# Altium schematic sheet E-modem-Send&Receive.SchDoc
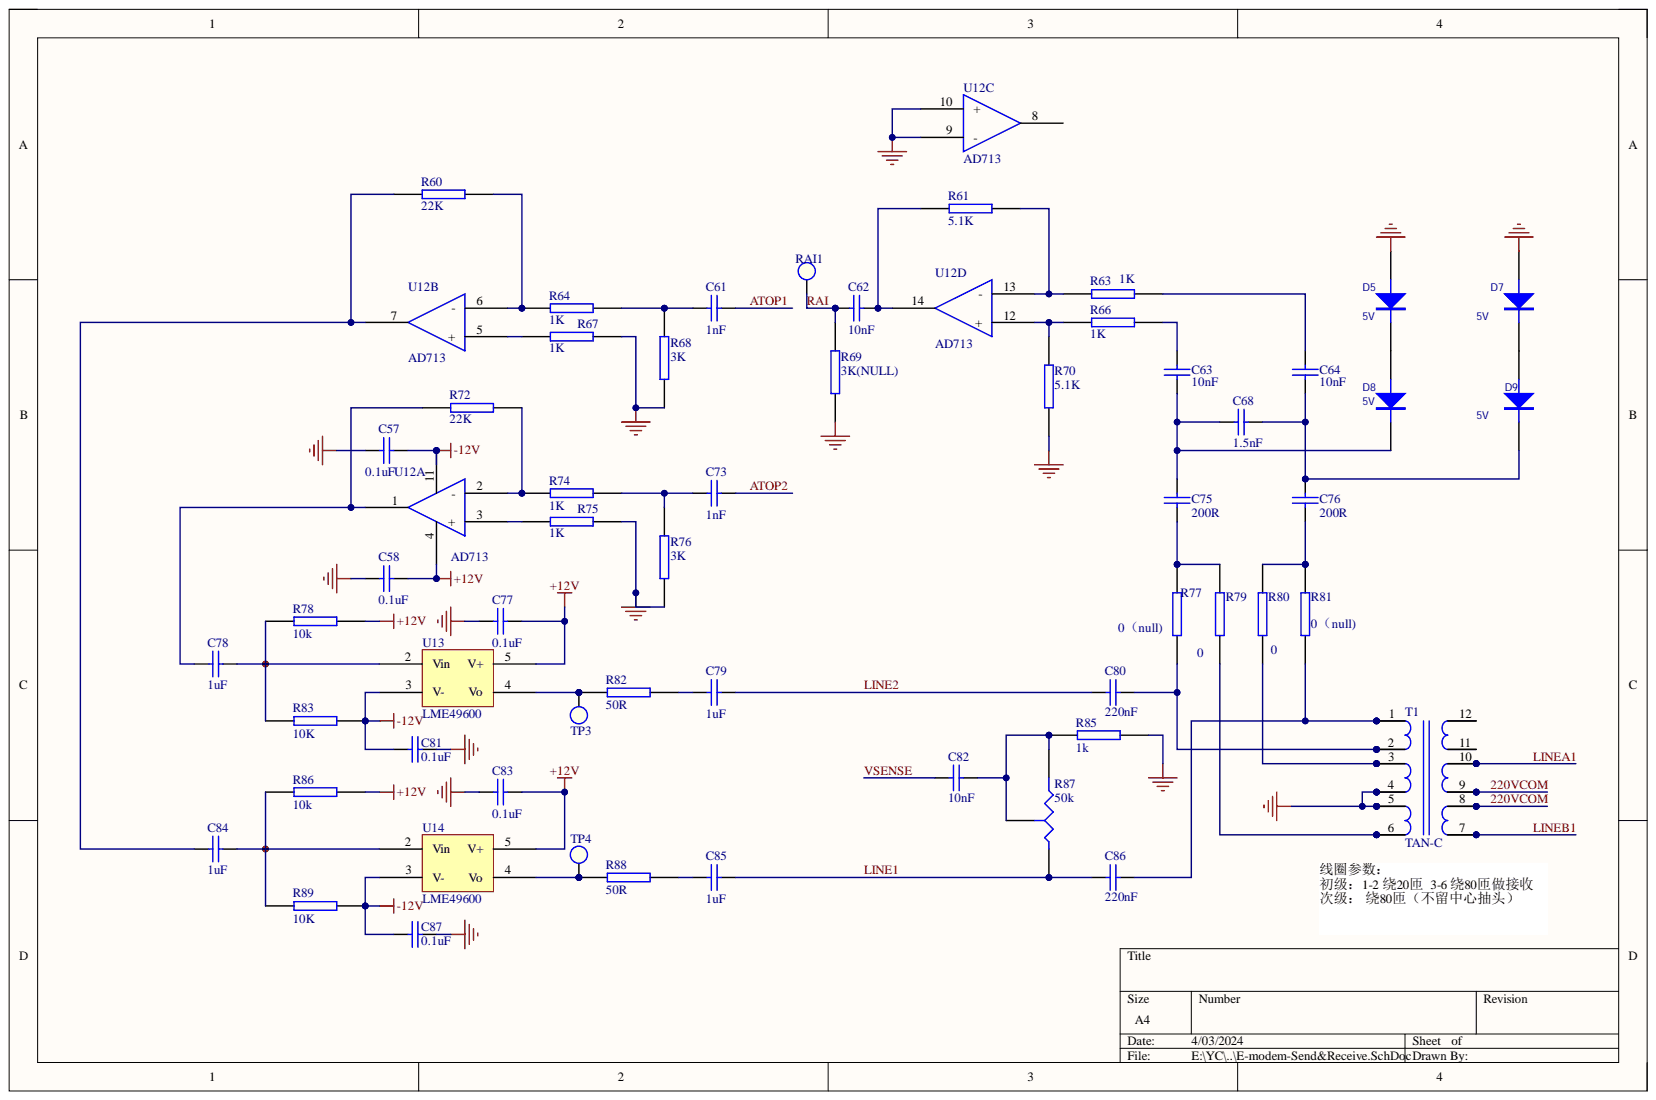
[diagram p0: E-modem-Send&Receive.SchDoc]

## Parts
C57  0.1uF  [0805C]
C58  0.1uF  [0805C]
C61  1nF  [0805C]
C62  10nF  [0805C]
C63  10nF  [0805C]
C64  10nF  [0805C]
C68  1.5nF  [0805C]
C73  1nF  [0805C]
C75  200R  [0805C]
C76  200R  [0805C]
C77  0.1uF  [0805C]
C78  1uF  [0805C]
C79  1uF  [0805C]
C80  220nF  [0805C]
C81  0.1uF  [0805C]
C82  10nF  [0805C]
C83  0.1uF  [0805C]
C84  1uF  [0805C]
C85  1uF  [0805C]
C86  220nF  [0805C]
C87  0.1uF  [0805C]
D5  5V  [1206D]
D7  5V  [1206D]
D8  5V  [1206D]
D9  5V  [1206D]
R60  22K  [0805]
R61  5.1K  [0805]
R63  1K  [0805]
R64  1K  [0805]
R66  1K  [0805]
R67  1K  [0805]
R68  3K  [0805]
R69  3K(NULL)  [0805]
R70  5.1K  [0805]
R72  22K  [0805]
R74  1K  [0805]
R75  1K  [0805]
R76  3K  [0805]
R77  0（null)  [0805]
R78  10k  [0805]
R79  0  [0805]
R80  0  [0805]
R81  0（null)  [0805]
R82  50R  [0805]
R83  10K  [0805]
R85  1k  [0805]
R86  10k  [0805]
R87  50k  [VR4_1]
R88  50R  [0805]
R89  10K  [0805]
RAI1  GND  [TERM]  (value not drawn)
T1  TAN-C  [TAN-C/RM10]
TP3  TERM  [TERM]  (value not drawn)
TP4  TERM  [TERM]  (value not drawn)
U12  AD713  [DIP14]  (parts A,B,C,D)
U13  LME49600  [TO-263-5]
U14  LME49600  [TO-263-5]

## Nets
25: T1.25
26: T1.26
27: T1.27
28: T1.28
+12V: C58.1 C77.1 C83.1 R78.2 R86.2 U12.4 U13.5(V+) U14.5(V+)
-12V: C57.1 C81.1 C87.2 R83.2 R89.1 U12.11 U13.3(V-) U14.3(V-)
GND: C57.2 C58.2 C77.2 C81.2 C83.2 C87.1 D5.1 D7.1 R67.1 R68.1 R69.1 R70.1 R75.2 R76.1 R85.2 T1.4 T1.5 T1.14 T1.15 U12.9(-) U12.10(+)
220VCOM: T1.8 T1.9 T1.23 T1.24
ATOP1: C61.2
ATOP2: C73.2
LINE1: C85.2 C86.1 R87.1
LINE2: C79.1 C80.1
LINEA1: T1.10 T1.19
LINEB1: T1.7 T1.22
RAI: C62.1 R69.2 RAI1.1
VSENSE: C82.1
NetC61_1: C61.1 R64.2 R68.2
NetC62_2: C62.2 R61.1 U12.14
NetC63_1: C63.1 R66.2
NetC63_2: C63.2 C68.2 C75.2 D8.2
NetC64_1: C64.1 R63.2
NetC64_2: C64.2 C68.1 C76.2 D9.2
NetC73_1: C73.1 R74.2 R76.2
NetC75_1: C75.1 R77.2 R79.2
NetC76_1: C76.1 R80.2 R81.1
NetC78_1: C78.1 R72.1 U12.1
NetC78_2: C78.2 R78.1 R83.1 U13.2(Vin)
NetC79_2: C79.2 R82.2
NetC80_2: C80.2 R77.1 T1.2 T1.18
NetC82_2: C82.2 R85.1 R87.2 R87.3
NetC84_1: C84.1 R60.1 U12.7
NetC84_2: C84.2 R86.1 R89.2 U14.2(Vin)
NetC85_1: C85.1 R88.2
NetC86_2: C86.2 R81.2 T1.1 T1.17
NetD5_2: D5.2 D8.1
NetD7_2: D7.2 D9.1
NetR60_2: R60.2 R64.1 U12.6(-)
NetR61_2: R61.2 R63.1 U12.13(-)
NetR66_1: R66.1 R70.2 U12.12(+)
NetR67_2: R67.2 U12.5(+)
NetR72_2: R72.2 R74.1 U12.2(-)
NetR75_1: R75.1 U12.3(+)
NetR79_1: R79.1 T1.6 T1.16
NetR80_1: R80.1 T1.3 T1.13
NetR82_1: R82.1 TP3.1 U13.4(Vo)
NetR88_1: R88.1 TP4.1 U14.4(Vo)
NetT1_11: T1.11 T1.20
NetT1_12: T1.12 T1.21

Not connected: U12 8

## Library data of the parts
C57 C58 C61-C64 C68 C73 C75-C87 = CAP: Capacitor; Component Kind: Standard
D5 D7-D9 = DIODE: Component Kind: Standard; Description: Diode
R60 R61 R63 R64 R66-R70 R72 R74-R83 R85 R86 R88 R89 = RES2: Component Kind: Standard
R87 = RESISTOR TAPPED: Component Kind: Standard
RAI1 = TERM: Component Kind: Standard
T1 = TAN-C: T?; Component Kind: Standard
U12 = LM324: Component Kind: Standard
U13 U14 = Component_1: Component Kind: Standard; Library Name: Schlib1.SchLib
TP3 TP4 = TERM: Component Kind: Standard
